# Altium schematic sheet PWR1.SchDoc(PWR1)
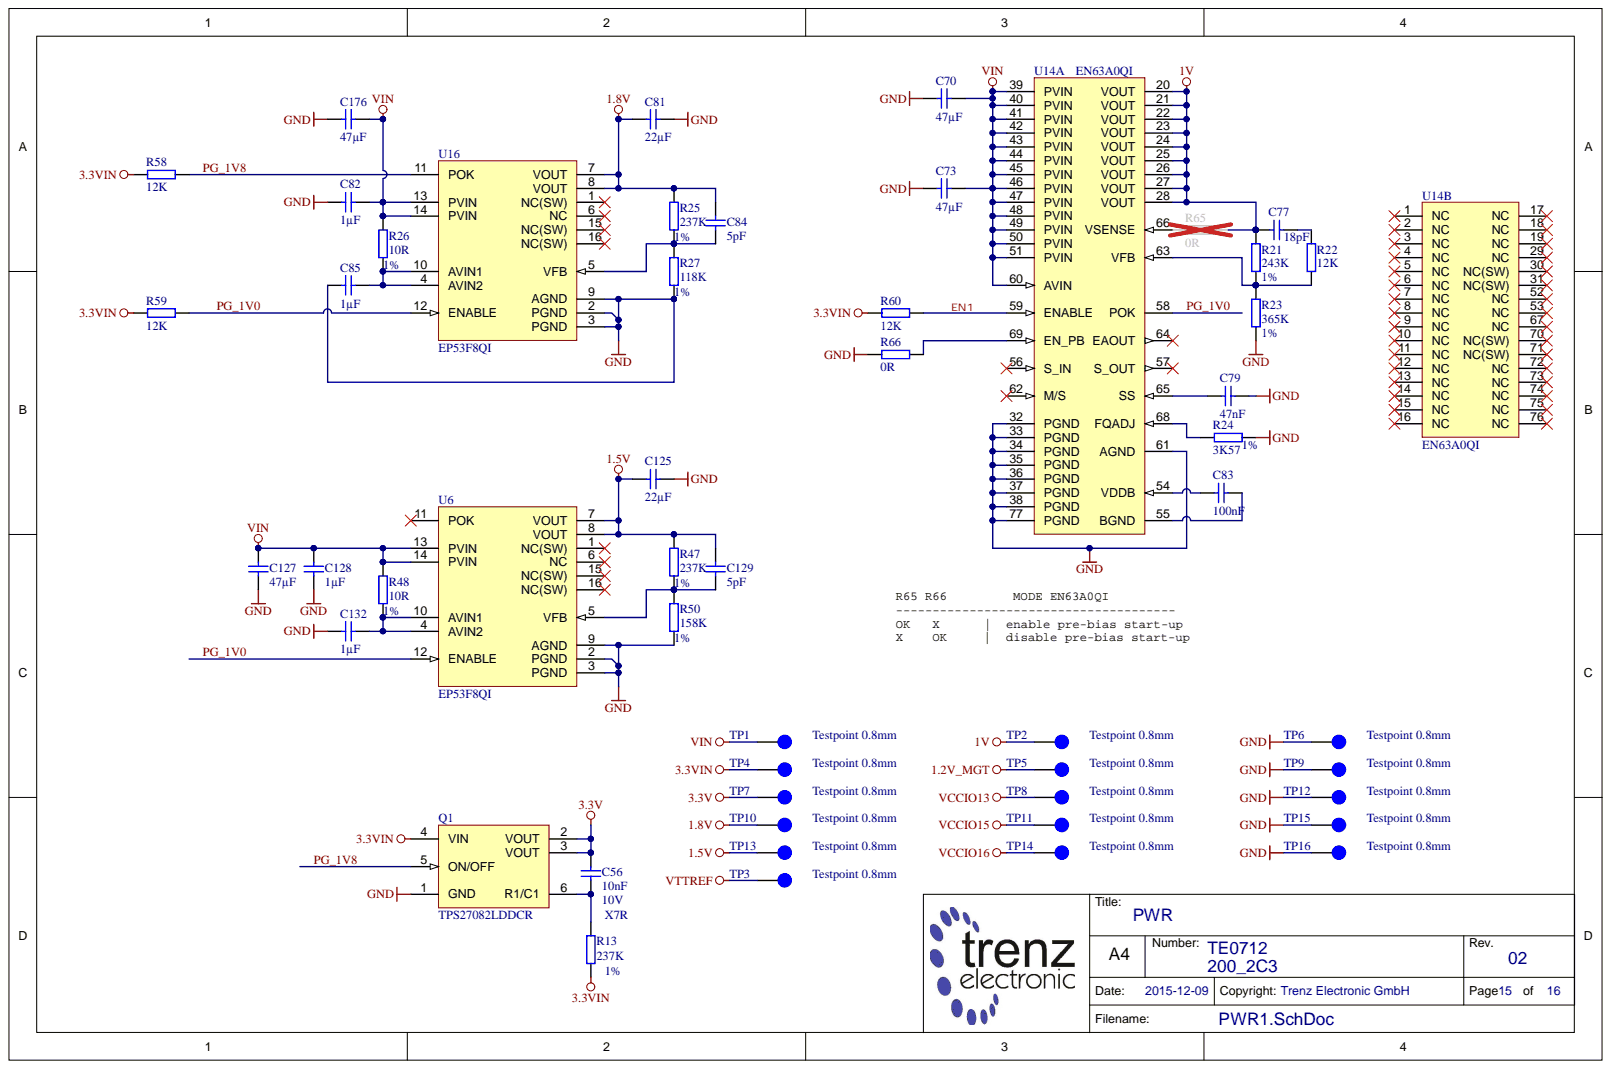
[diagram p0: PWR1.SchDoc]

## Parts
C56  10nF  Voltage: 10V  [CAPC0603X33N]
C70  47µF  [CAPC2012X135N]
C73  47µF  [CAPC2012X135N]
C77  18pF  [CAPC1005X55N]
C79  47nF  [CAPC0603X33N]
C81  22µF  [CAPC2012X135N]
C82  1µF  [CAPC1005X55N]
C83  100nF  [CAPC0603X33N]
C84  5pF  [CAPC0603X33N]
C85  1µF  [CAPC1005X55N]
C125  22µF  [CAPC2012X135N]
C127  47µF  [CAPC2012X135N]
C128  1µF  [CAPC1005X55N]
C129  5pF  [CAPC0603X33N]
C132  1µF  [CAPC1005X55N]
C176  47µF  [CAPC2012X135N]
Q1  TPS27082LDDCR  [SOT95P280X110-6N]
R13  237K  Tolerance: 1%  [RESC1005X40N]
R21  243K  Tolerance: 1%  [RESC1005X40N]
R22  12K  [RESC1005X40N]
R23  365K  Tolerance: 1%  [RESC1005X40N]
R24  3K57  Tolerance: 1%  [RESC1005X40N]
R25  237K  Tolerance: 1%  [RESC1005X40N]
R26  10R  Tolerance: 1%  [RESC1005X40N]
R27  118K  Tolerance: 1%  [RESC1005X40N]
R47  237K  Tolerance: 1%  [RESC1005X40N]
R48  10R  Tolerance: 1%  [RESC1005X40N]
R50  158K  Tolerance: 1%  [RESC1005X40N]
R58  12K  [RESC1005X40N]
R59  12K  [RESC1005X40N]
R60  12K  [RESC1005X40N]
R65  0R  [RESC1005X40N]
R66  0R  [RESC1005X40N]
TP1  Testpoint 0.8mm  [TP_0.8mm]
TP2  Testpoint 0.8mm  [TP_0.8mm]
TP3  Testpoint 0.8mm  [TP_0.8mm]
TP4  Testpoint 0.8mm  [TP_0.8mm]
TP5  Testpoint 0.8mm  [TP_0.8mm]
TP6  Testpoint 0.8mm  [TP_0.8mm]
TP7  Testpoint 0.8mm  [TP_0.8mm]
TP8  Testpoint 0.8mm  [TP_0.8mm]
TP9  Testpoint 0.8mm  [TP_0.8mm]
TP10  Testpoint 0.8mm  [TP_0.8mm]
TP11  Testpoint 0.8mm  [TP_0.8mm]
TP12  Testpoint 0.8mm  [TP_0.8mm]
TP13  Testpoint 0.8mm  [TP_0.8mm]
TP14  Testpoint 0.8mm  [TP_0.8mm]
TP15  Testpoint 0.8mm  [TP_0.8mm]
TP16  Testpoint 0.8mm  [TP_0.8mm]
U6  EP53F8QI  [EP53F8QI]
U14  EN63A0QI  [EN63A0QI]  (parts A,B)
U16  EP53F8QI  [EP53F8QI]

## Nets
1.2V_MGT: TP5.1
1.5V: C125.1 C129.1 R47.1 TP13.1 U6.7(VOUT) U6.8(VOUT)
1.8V: C81.1 C84.1 R25.1 TP10.1 U16.7(VOUT) U16.8(VOUT)
1V: C77.1 R21.1 R65.1 TP2.1 U14.20(VOUT) U14.21(VOUT) U14.22(VOUT) U14.23(VOUT) U14.24(VOUT) U14.25(VOUT) U14.26(VOUT) U14.27(VOUT) U14.28(VOUT)
3.3V: C56.1 Q1.2(VOUT) Q1.3(VOUT) TP7.1
3.3VIN: Q1.4(VIN) R13.2 R58.2 R59.2 R60.2 TP4.1
GND: C70.1 C73.1 C79.2 C81.2 C82.1 C85.1 C125.2 C127.1 C128.1 C132.1 C176.2 Q1.1(GND) R23.2 R24.2 R27.2 R50.2 R66.1 TP6.1 TP9.1 TP12.1 TP15.1 TP16.1 U6.2(PGND) U6.3(PGND) U6.9(AGND) U14.32(PGND) U14.33(PGND) U14.34(PGND) U14.35(PGND) U14.36(PGND) U14.37(PGND) U14.38(PGND) U14.61(AGND) U14.77(PGND) U16.2(PGND) U16.3(PGND) U16.9(AGND)
VCCIO13: TP8.1
VCCIO15: TP11.1
VCCIO16: TP14.1
VIN: C70.2 C73.2 C82.2 C127.2 C128.2 C176.1 R26.1 R48.1 TP1.1 U6.13(PVIN) U6.14(PVIN) U14.39(PVIN) U14.40(PVIN) U14.41(PVIN) U14.42(PVIN) U14.43(PVIN) U14.44(PVIN) U14.45(PVIN) U14.46(PVIN) U14.47(PVIN) U14.48(PVIN) U14.49(PVIN) U14.50(PVIN) U14.51(PVIN) U14.60(AVIN) U16.13(PVIN) U16.14(PVIN)
VTTREF: TP3.1
EN1: R60.1 U14.59(ENABLE)
PG_1V0: R59.1 U6.12(ENABLE) U14.58(POK) U16.12(ENABLE)
PG_1V8: Q1.5(ON/OFF) R58.1 U16.11(POK)
NetC56_2: C56.2 Q1.6(R1/C1) R13.1
NetC77_2: C77.2 R22.1
NetC79_1: C79.1 U14.65(SS)
NetC83_1: C83.1 U14.54(VDDB)
NetC83_2: C83.2 U14.55(BGND)
NetC84_2: C84.2 R25.2 R27.1 U16.5(VFB)
NetC85_2: C85.2 R26.2 U16.4(AVIN2) U16.10(AVIN1)
NetC129_2: C129.2 R47.2 R50.1 U6.5(VFB)
NetC132_2: C132.2 R48.2 U6.4(AVIN2) U6.10(AVIN1)
NetR21_2: R21.2 R22.2 R23.1 U14.63(VFB)
NetR24_1: R24.1 U14.68(FQADJ)
NetR65_2: R65.2 U14.66(VSENSE)
NetR66_2: R66.2 U14.69(EN_PB)

Not connected: U6 1(NC(SW)) 6(NC) 11(POK) 15(NC(SW)) 16(NC(SW)); U14 1(NC) 2(NC) 3(NC) 4(NC) 5(NC) 6(NC) 7(NC) 8(NC) 9(NC) 10(NC) 11(NC) 12(NC) 13(NC) 14(NC) 15(NC) 16(NC) 17(NC) 18(NC) 19(NC) 29(NC) 30(NC(SW)) 31(NC(SW)) 52(NC) 53(NC) 56(S_IN) 57(S_OUT) 62(M/S) 64(EAOUT) 67(NC) 70(NC(SW)) 71(NC(SW)) 72(NC) 73(NC) 74(NC) 75(NC) 76(NC); U16 1(NC(SW)) 6(NC) 15(NC(SW)) 16(NC(SW))

## Library data of the parts
C56 = 26312: KON 10nF X7R 10V SMD 10%; Database Table Name: C_0603_0201; H-ART: GRM033R71A103KA01D; Mixed: SMT; Preferred: Yes; Value: 10n; AN: 26312; Case: 0603 (0201); Component Kind: Standard; Library Name: TE.DbLib; Technology: X7R; Tolerance: 10%
C70 C73 C127 C176 = 24718: KON 47µF 6,3V  X5R SMD 20%; Database Table Name: C_2012_0805; Mixed: SMT; Preferred: Yes; Value: 47u; Voltage: 6.3V; AN: 24718; Case: 2012 (0805); Component Kind: Standard; Library Name: TE.DbLib; Technology: X5R; Tolerance: 20%
C77 = 24228: KON 18pF NPO 50V SMD; Database Table Name: C_1005_0402; Mixed: SMT; Preferred: Yes; Value: 18p; AN: 24228; Case: 1005 (0402); Component Kind: Standard; Library Name: TE.DbLib; Technology: NPO; Voltage: 50V
C79 = 24924: KON 47nF X5R 6.3V SMD 10%; Database Table Name: C_0603_0201; Mixed: SMT; Preferred: Yes; Value: 47n; AN: 24924; Case: 0603 (0201); Component Kind: Standard; Library Name: TE.DbLib; Technology: X5R; Tolerance: 10%; Voltage: 6.3V
C81 C125 = 23410: KON 22µF 6,3V  X5R SMD; Database Table Name: C_2012_0805; H-ART: CL21A226MQQNNNG; Mixed: SMT; Value: 22u; Voltage: 6.3V; AN: 23410; Case: 2012 (0805); Component Kind: Standard; Library Name: TE.DbLib; Technology: X5R
C82 C85 C128 C132 = 23453: KON 1µF 6.3V X5R SMD 10%; Database Table Name: C_1005_0402; H-ART: CL05A105KQ5NNNC; Mixed: SMT; Preferred: Yes; Value: 1u; Voltage: 6.3; AN: 23453; Case: 1005 (0402); Component Kind: Standard; Library Name: TE.DbLib; Technology: X5R; Tolerance: 10%
C83 = 24915: KON 100nF 6.3V X5R SMD 10%; Database Table Name: C_0603_0201; Mixed: SMT; Preferred: Yes; Value: 100n; AN: 24915; Case: 0603 (0201); Component Kind: Standard; Library Name: TE.DbLib; Technology: X5R; Tolerance: 10%; Voltage: 6.3V
C84 C129 = 24919: KON 5pF NP0 25V SMD 5%; Database Table Name: C_0603_0201; H-ART: GRM0335C1E5R0C; Mixed: SMT; Preferred: Yes; Value: 5p; AN: 24919; Case: 0603 (0201); Component Kind: Standard; Library Name: TE.DbLib; Technology: NP0; Tolerance: 5%; Voltage: 25V
Q1 = 25004: Database Table Name: SWITCH; Mixed: SMT; Preferred: Yes; AN: 25004; Case: SOT23-6; Component Kind: Standard; Description: TPS27082LDDCR; Library Name: TE.DbLib; Trenz-Stock: Yes; HelpURL: B:\AD\adlib\datasheet\TPS27082L
R13 R25 R47 = 24549: WID SMD 237K 1% 0,063W; Database Table Name: R_1005_0402; H-ART: RMCF0402FT237K; Mixed: SMT; Preferred: Yes; AN: 24549; Case: 1005 (0402); Component Kind: Standard; Library Name: TE.DbLib; Value: 237K
R21 = 25966: WID SMD 243K 1% 0,063W; Database Table Name: R_1005_0402; Mixed: SMT; AN: 25966; Case: 1005 (0402); Component Kind: Standard; Library Name: TE.DbLib; Value: 243K
R22 R58-R60 = 22598: WID SMD 12K 1% 0,063W; Database Table Name: R_1005_0402; Mixed: SMT; Preferred: Yes; AN: 22598; Case: 1005 (0402); Component Kind: Standard; Library Name: TE.DbLib; Value: 12K
R23 = 25967: WID SMD 365K 1% 0,063W; Database Table Name: R_1005_0402; Mixed: SMT; Preferred: Yes; AN: 25967; Case: 1005 (0402); Component Kind: Standard; Library Name: TE.DbLib; Value: 365K
R24 = 23145: WID SMD 3K57 1% 0,063W; Database Table Name: R_1005_0402; Mixed: SMT; Value: 3.57K; AN: 23145; Case: 1005 (0402); Component Kind: Standard; Library Name: TE.DbLib
R26 R48 = 22844: WID SMD 10R 1% 0,063W; Database Table Name: R_1005_0402; Mixed: SMT; Preferred: Yes; Value: 10; AN: 22844; Case: 1005 (0402); Component Kind: Standard; Library Name: TE.DbLib
R27 = 24713: WID SMD 118K 1% 0,063W; Database Table Name: R_1005_0402; Mixed: SMT; Preferred: Yes; AN: 24713; Case: 1005 (0402); Component Kind: Standard; Library Name: TE.DbLib; Value: 118K
R50 = 24550: WID SMD 158K 1% 0,063W; Database Table Name: R_1005_0402; Mixed: SMT; Preferred: Yes; AN: 24550; Case: 1005 (0402); Component Kind: Standard; Library Name: TE.DbLib; Value: 158k
R65 R66 = 22738: WID SMD 0R; Database Table Name: R_1005_0402; Mixed: SMT; Preferred: Yes; Value: 0; AN: 22738; Case: 1005 (0402); Component Kind: Standard; Library Name: TE.DbLib
TP1-TP16 = 26289: Database Table Name: PCB; Mixed: 1; AN: 26289; Component Kind: Standard; Description: Testpoint 0.8mm; Library Name: TE.DbLib
U6 U16 = 24487: Database Table Name: DCDC; Mixed: SMT; Preferred: Yes; AN: 24487; Component Kind: Standard; Description: EP53F8QI; Library Name: TE.DbLib; Trenz-Stock: Yes; HelpURL: B:\AD\adlib\datasheet\EP53F8QI
U14 = 24937: Database Table Name: DCDC; Mixed: SMT; Preferred: Yes; AN: 24937; Component Kind: Standard; Description: EN63A0QI; Library Name: TE.DbLib; Trenz-Stock: Yes; HelpURL: B:\AD\adlib\datasheet\EN63A0QI
